# Altium schematic sheet Sheet2.SchDoc(Sheet2)
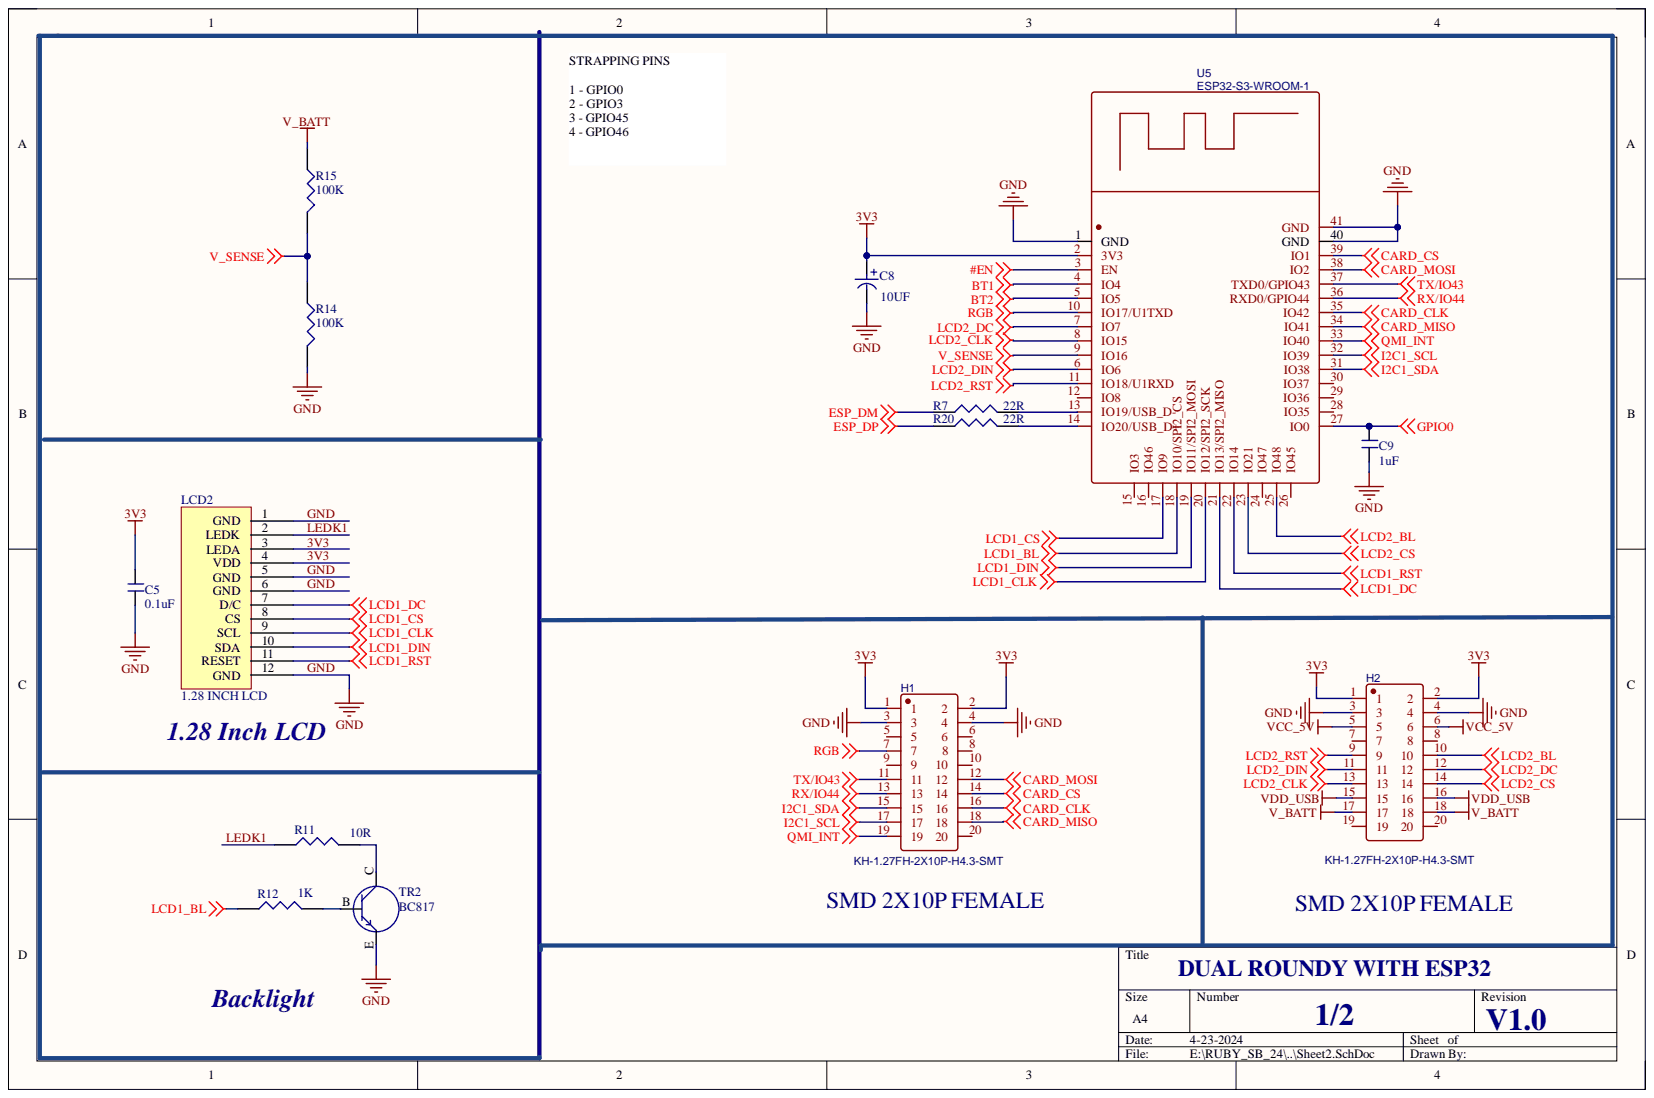
[diagram p0: Sheet2.SchDoc]

## Parts
C5  0.1uF  [C_0603]
C8  10UF  [C_0603]
C9  1uF  [C_0603]
H1  KH-1.27FH-2X10P-H4.3-SMT  [SMD 2X10P 1.27MM FEMALE]
H2  KH-1.27FH-2X10P-H4.3-SMT  [SMD 2X10P 1.27MM FEMALE]
LCD2  1.28 INCH LCD  [1.28 ROUND NON TOUCH]
R7  22R  [R_0603]
R11  10R  [R_0603]
R12  1K  [R_0603]
R14  100K  [R_0603]
R15  100K  [R_0603]
R20  22R  [R_0603]
TR2  BC817  [SOT23]
U5  ESP32-S3-WROOM-1  [ESP32-S3-WROOM1]

## Nets
#EN: U5.3(EN)
BT1: U5.4(IO4)
BT2: U5.5(IO5)
CARD_CLK: H1.16 U5.35(IO42)
CARD_CS: H1.14 U5.39(IO1)
CARD_MISO: H1.18 U5.34(IO41)
CARD_MOSI: H1.12 U5.38(IO2)
ESP_DM: R7.1
ESP_DP: R20.1
GPIO0: C9.2 U5.27(IO0)
I2C1_SCL: H1.17 U5.32(IO39)
I2C1_SDA: H1.15 U5.31(IO38)
LCD1_BL: R12.1 U5.18(IO10/SPI2_CS)
LCD1_CLK: LCD2.9(SCL) U5.20(IO12/SPI2_SCK)
LCD1_CS: LCD2.8(CS) U5.17(IO9)
LCD1_DC: LCD2.7(D/C) U5.21(IO13/SPI2_MISO)
LCD1_DIN: LCD2.10(SDA) U5.19(IO11/SPI2_MOSI)
LCD1_RST: LCD2.11(RESET) U5.22(IO14)
LCD2_BL: H2.10 U5.25(IO48)
LCD2_CLK: H2.13 U5.8(IO15)
LCD2_CS: H2.14 U5.23(IO21)
LCD2_DC: H2.12 U5.7(IO7)
LCD2_DIN: H2.11 U5.6(IO6)
LCD2_RST: H2.9 U5.11(IO18/U1RXD)
QMI_INT: H1.19 U5.33(IO40)
RGB: H1.7 U5.10(IO17/U1TXD)
RX/IO44: H1.13 U5.36(RXD0/GPIO44)
TX/IO43: H1.11 U5.37(TXD0/GPIO43)
V_BATT: H2.17 H2.18 R15.1
V_SENSE: R14.1 R15.2 U5.9(IO16)
VCC_5V: H2.5 H2.6
VDD_USB: H2.15 H2.16
3V3: C5.2 C8.1 H1.1 H1.2 H2.1 H2.2 LCD2.3(LEDA) LCD2.4(VDD) U5.2(3V3)
GND: C5.1 C8.2 C9.1 H1.3 H1.4 H2.3 H2.4 LCD2.1(GND) LCD2.5(GND) LCD2.6(GND) LCD2.12(GND) R14.2 TR2.E U5.1(GND) U5.40(GND) U5.41(GND)
LEDK1: LCD2.2(LEDK) R11.2
NetR7_2: R7.2 U5.13(IO19/USB_D-)
NetR11_1: R11.1 TR2.C
NetR12_2: R12.2 TR2.B
NetR20_2: R20.2 U5.14(IO20/USB_D+)

Not connected: H1 5 6 8 9 10 20; H2 7 8 19 20; U5 12(IO8) 15(IO3) 16(IO46) 24(IO47) 26(IO45) 28(IO35) 29(IO36) 30(IO37)

## Library data of the parts
C5 C9 = CAP_NONPOLAR: Component Kind: Standard; Library Name: dxp_pasl_lib.IntLib
C8 = CAP_ELE: Component Kind: Standard; Library Name: MIX.SchLib
H1 H2 = KH-1.27FH-2X10P-H4.3-SMT: BOM_Manufacturer: kinghelm(金航标); Contributor: LCSC; BOM_JLCPCB Part Class: Extended Part; BOM_Manufacturer Part: KH-1.27FH-2X10P-H4.3-SMT; BOM_Supplier: LCSC; BOM_Supplier Part: C2938399; Component Kind: Standard; spicePre: U; spiceSymbolName: KH-1.27FH-2X10P-H4.3-SMT
LCD2 = LCD ROUND: 1.28 INCH ROUND LCD GC9A01; Component Kind: Standard; Library Name: dxp_pasl_lib.IntLib
R7 R11 R12 R14 R15 R20 = RES: Component Kind: Standard; Library Name: dxp_pasl_lib.IntLib
TR2 = TRANSISTER_NPN: Component Kind: Standard; Library Name: dxp_pasl_lib.IntLib
U5 = ESP32-S3-WROOM-1(N16): BOM_Manufacturer: ESPRESSIF(乐鑫); BOM_Manufacturer Part: ESP32-S3-WROOM-1-N16; Contributor: lcsc; BOM_JLCPCB Part Class: Extended Part; BOM_Supplier: LCSC; BOM_Supplier Part: C2913199; Component Kind: Standard; link: https://atta.szlcsc.com/upload/public/pdf/source/20220628/A2AC12B3C87735CAEB7EBE2DBE80936C.pdf; spicePre: U; spiceSymbolName: ESP32-S3-WROOM-1(N16)
